# Altium schematic sheet 13-M2_OCP_GNSS.SchDoc(13-M2_OCP_GNSS)
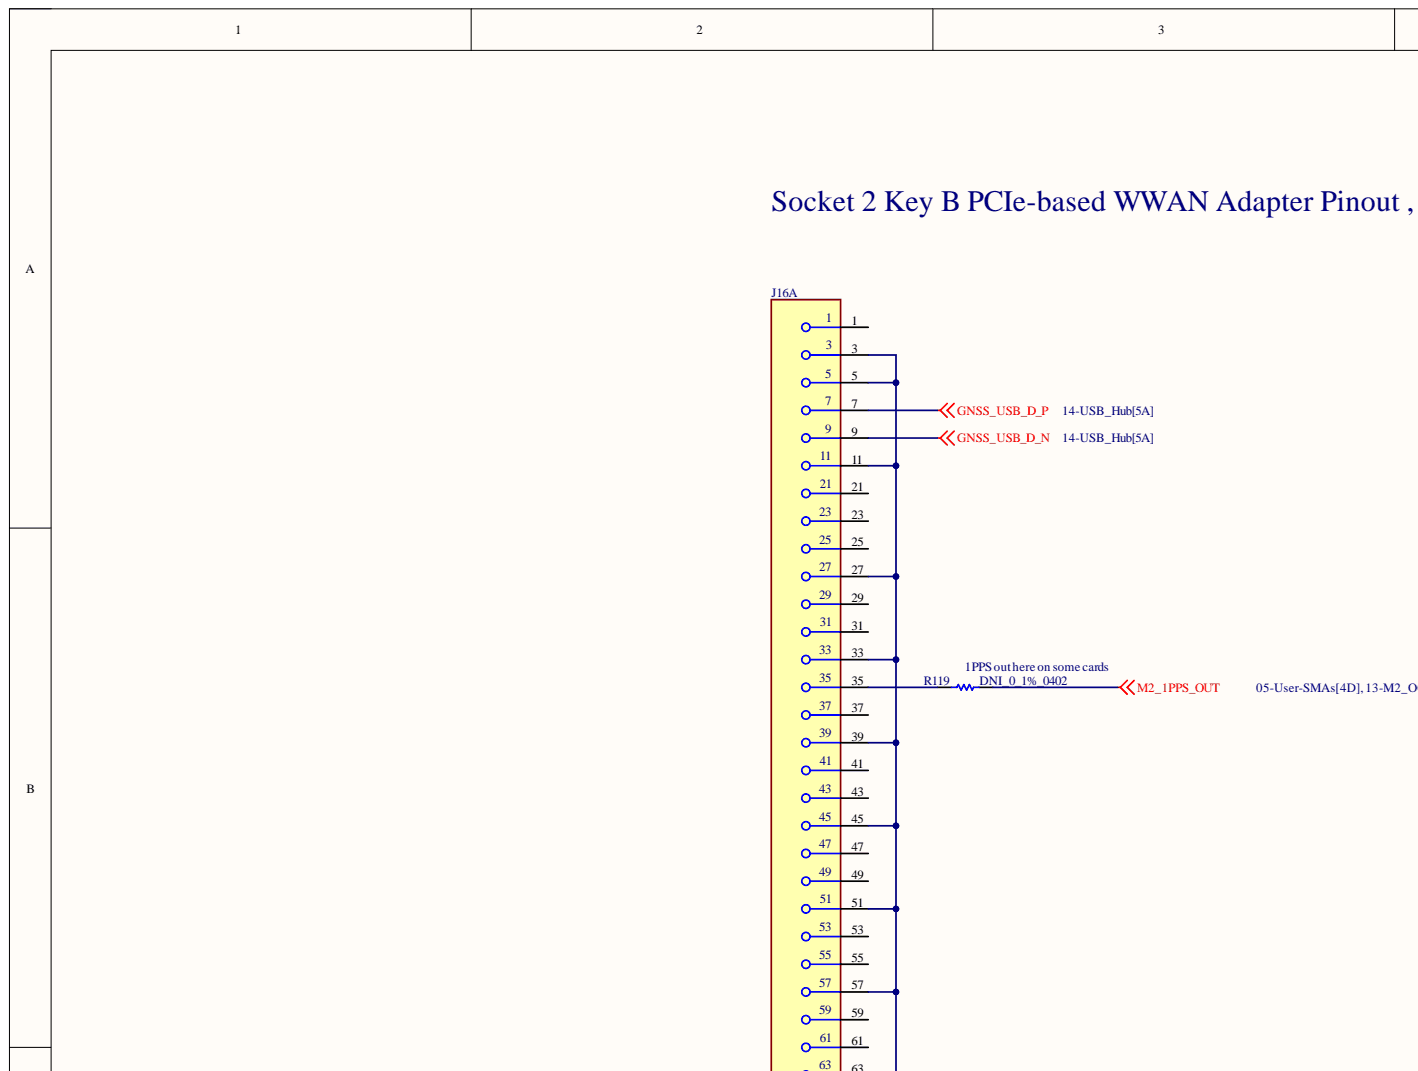
[diagram p0: 13-M2_OCP_GNSS.SchDoc, top left]
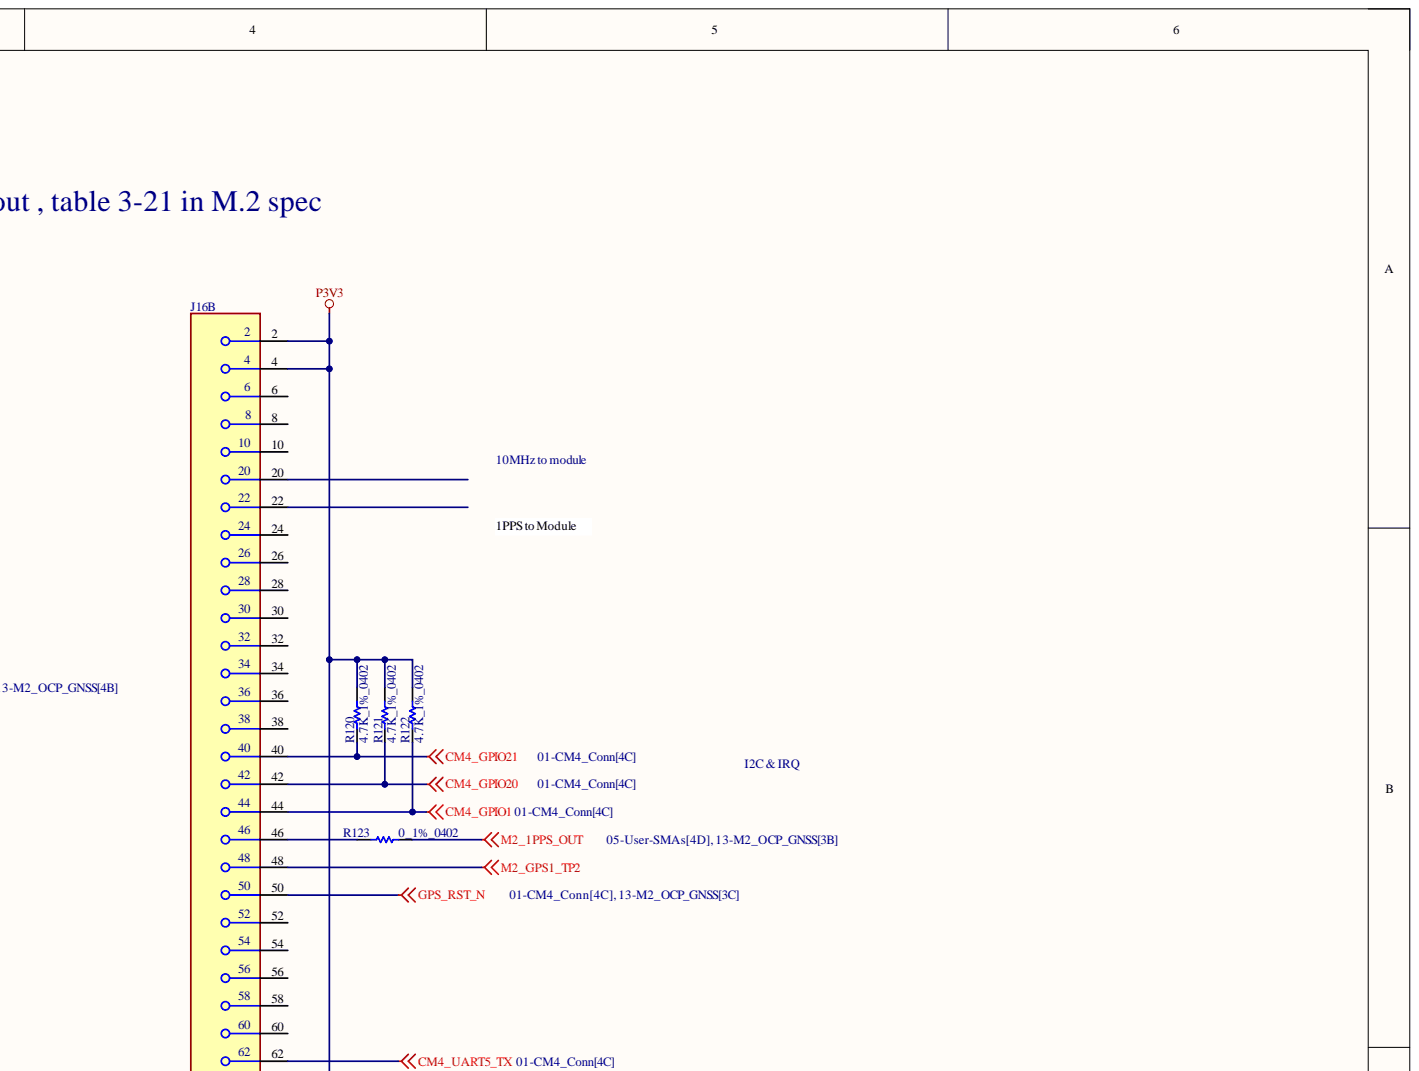
[diagram p1: 13-M2_OCP_GNSS.SchDoc, top right]
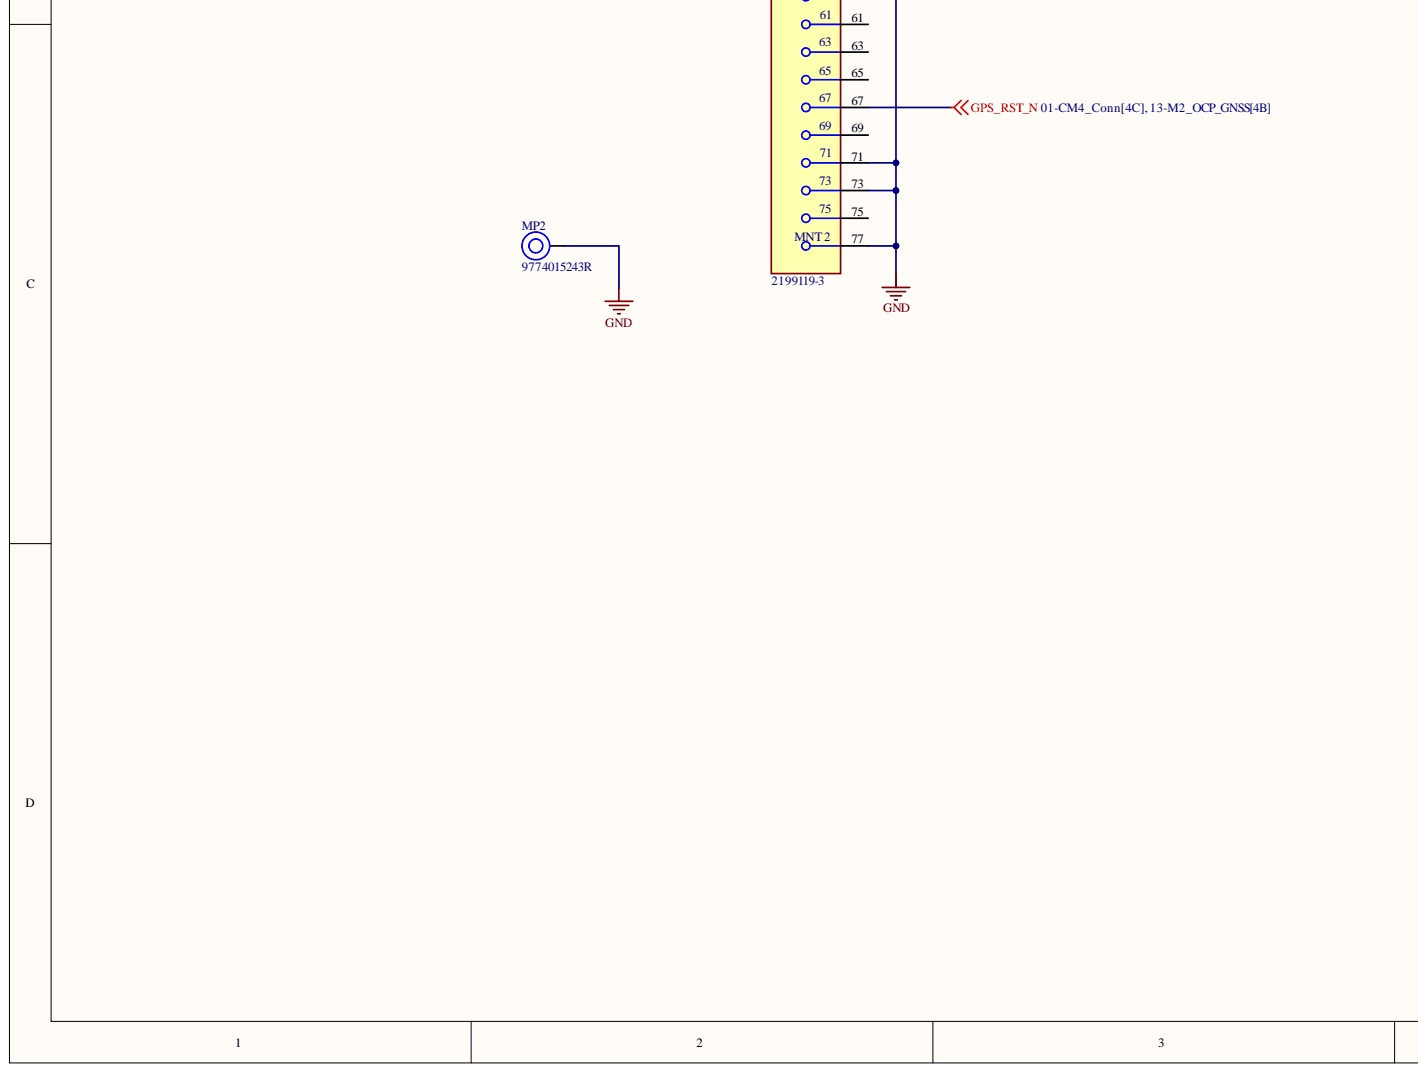
[diagram p2: 13-M2_OCP_GNSS.SchDoc, bottom left]
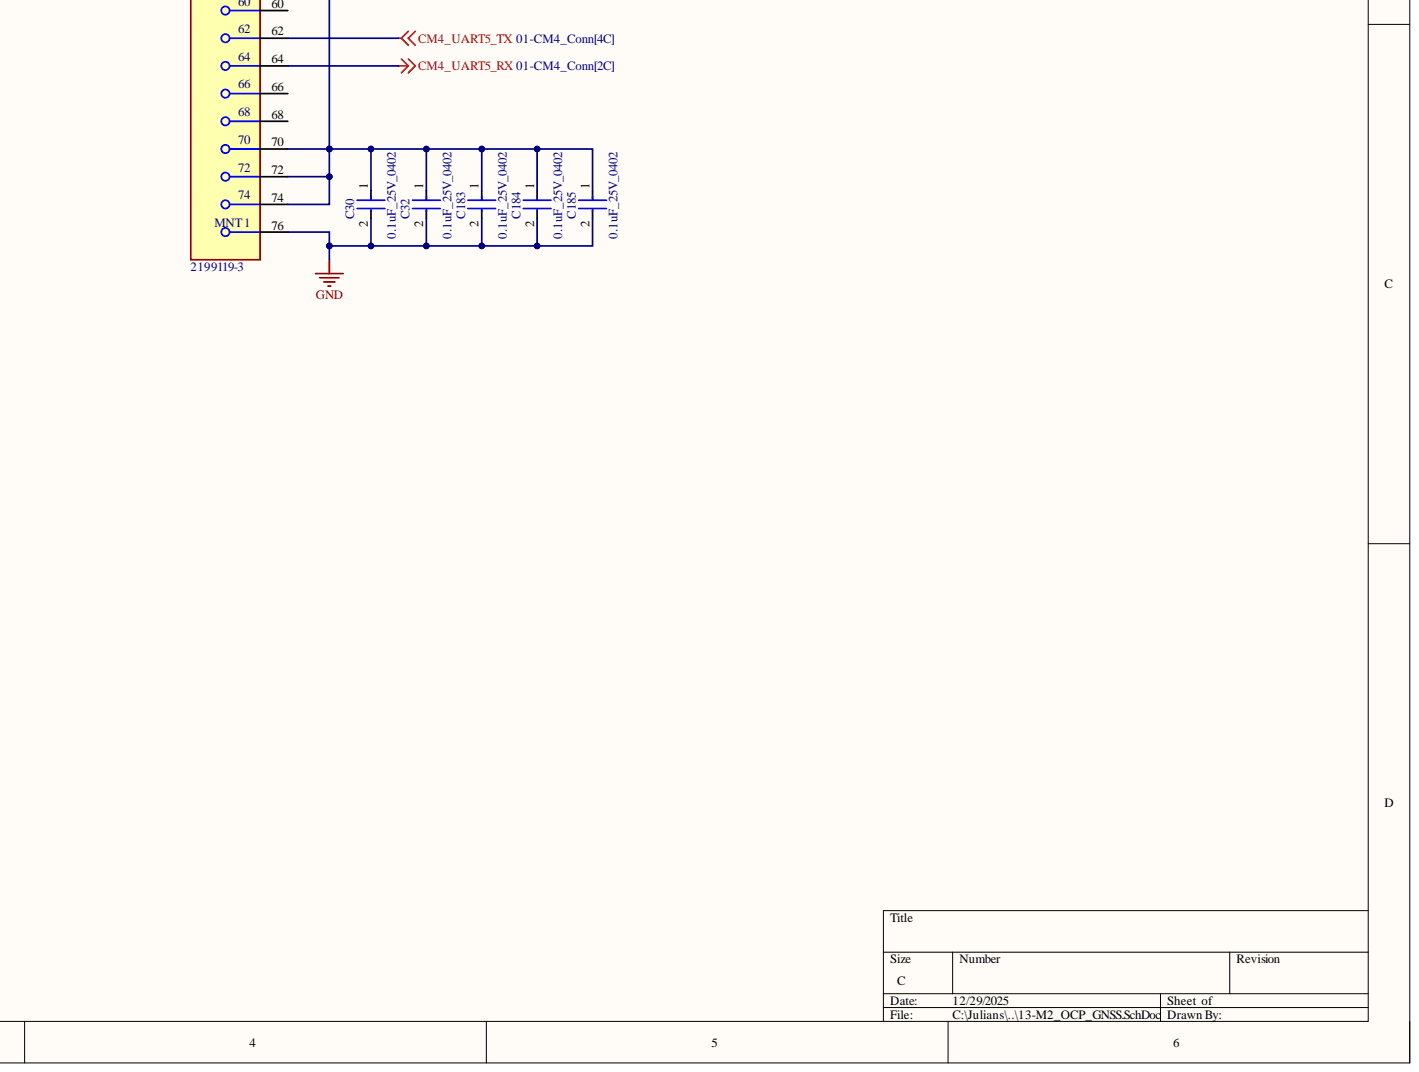
[diagram p3: 13-M2_OCP_GNSS.SchDoc, bottom right]

## Parts
C30  0.1uF_25V_0402  [FP-0402-L_1_0_1-W_0_5_0_1-IPC_C]
C32  0.1uF_25V_0402  [FP-0402-L_1_0_1-W_0_5_0_1-IPC_C]
C183  0.1uF_25V_0402  [FP-0402-L_1_0_1-W_0_5_0_1-IPC_C]
C184  0.1uF_25V_0402  [FP-0402-L_1_0_1-W_0_5_0_1-IPC_C]
C185  0.1uF_25V_0402  [FP-0402-L_1_0_1-W_0_5_0_1-IPC_C]
J16  2199119-3  [FP-2199119-3-MFG]  (parts A,B)
MP2  9774015243R  [9774015243]
R119  DNI_0_1%_0402  [RESC1005X40X25LL05T05]  (no BOM)
R120  4.7K_1%_0402  [RESC1005X40X25NL10T10]
R121  4.7K_1%_0402  [RESC1005X40X25NL10T10]
R122  4.7K_1%_0402  [RESC1005X40X25NL10T10]
R123  0_1%_0402  [RESC1005X40X25LL05T05]

## Nets
CM4_GPIO1: J16.44 R122.1
CM4_GPIO20: J16.42 R121.1
CM4_GPIO21: J16.40 R120.1
CM4_UART5_RX: J16.64
CM4_UART5_TX: J16.62
GND: C30.2 C32.2 C183.2 C184.2 C185.2 J16.3 J16.5 J16.11 J16.27 J16.33 J16.39 J16.45 J16.51 J16.57 J16.71 J16.73 J16.76 J16.77 MP2.1
GNSS_USB_D_N: J16.9
GNSS_USB_D_P: J16.7
GPS_RST_N: J16.50 J16.67
M2_1PPS_OUT: R119.2 R123.2
M2_GPS1_TP2: J16.48
P3V3: C30.1 C32.1 C183.1 C184.1 C185.1 J16.2 J16.4 J16.70 J16.72 J16.74 R120.2 R121.2 R122.2
NetJ16_35: J16.35 R119.1
NetJ16_46: J16.46 R123.1

Not connected: J16 1 6 8 10 20 21 22 23 24 25 26 28 29 30 31 32 34 36 37 38 41 43 47 49 52 53 54 55 56 58 59 60 61 63 65 66 68 69 75

## Library data of the parts
C30 C32 C183-C185 = CMP-2008-02519-2: General Purpose Ceramic Capacitor, 0402, 100nF, 10%, X7R, 15%, 25V; Capacitance: 100nF; Case Code (Imperial): 0402; Case Code (Metric): 1005; Case/Package: 0402; Component Kind: Standard; Construction: Flat; Depth: 0.5mm; Dielectric: X7R; Lead Free: Lead Free; Length: 1mm; Library Name: Altium Content Vault; Max Operating Temperature: 125°C; Min Operating Temperature: -55°C; Mount: Surface Mount; Number of Pins: 2; Package Quantity: 1; Packaging: Tape and Reel; Radiation Hardening: No; REACH SVHC: No SVHC; RoHS Compliant: Yes; Series: -; Termination: SMD/SMT; Thickness: 0.022inch; Tolerance: 10%; Voltage: 25V; Voltage Rating: 25V; Voltage Rating (DC): 25V; Width: 0.02inch
J16 = CMP-03365-000004-1: CONN M.2 FEMALE 67POS 0.020 GOLD; Contact Current Rating: 500mA; Insulation Resistance: 500MR; Max Voltage Rating (AC): 50V; Number of Contacts: 67; PCB Mounting Orientation: Right Angle; Pitch: 0.5mm; Row Spacing: 7.55mm; Color: Black; Component Kind: Standard; Contact Material: Copper Alloy; Contact Plating: Gold; Current Rating: 500mA; ELV: Compliant; Gender: Female; Height: 3.2mm; Housing Color: Black; Housing Material: Thermoplastic; Insulation Material: Thermoplastic; Length: 0.862inch; Library Name: Altium Content Vault; Max Operating Temperature: 80°C; Min Operating Temperature: -40°C; Mount: Surface Mount; Number of Positions: 67; Number of Rows: 2; Orientation: Right Angle; Package Quantity: 1200; Packaging: Tape and Reel; Radiation Hardening: No; RoHS Compliant: Yes; Termination: Solder; Voltage Rating: 50V; Width: 8.7mm
MP2 = CMP-03913-59774847-1: 9774015243R Spacer Material: Steel, Tin-Plated Mounting: SMT Series: WA-SMST Thread D: M2; Outside Diameter: 4.3434mm; Plating: Tin; Threaded/Unthreaded: Threaded; Component Kind: Standard; Height: 1.6mm; Length: 1.5mm; Library Name: Altium Content Vault; Material: Steel; Max Operating Temperature: 150°C; Min Operating Temperature: -55°C; NexarPartId: 59774847; Packaging: Tape and Reel; REACH SVHC: Unknown; RoHS: Compliant
R119 R123 = CMP-2000-07451-1: Chip Resistor, 0 Ohm, 0.1 W, -55 to 155 degC, 0402 (1005 Metric), RoHS, Tape and Reel; Manufacturer: Panasonic; Max Power Dissipation: 100mW; Resistance: 0mOhm; Temperature Coefficient: 600ppm/��C; Tolerance: 5%; Voltage Rating: 50V; Case Code (Imperial): 0402; Case Code (Metric): 1005; Case/Package: 0402; Composition: ThickFilm; Contact Plating: Tin; Datasheet URL: https://industrial.panasonic.com/cdbs/www-data/pdf/RDA0000/AOA0000C301.pdf; Datasheet Version: 03/2015; Depth: 0.5mm; Height: 0.35mm; Lead Free: LeadFree; Length: 1mm; Library Name: Altium Content Vault; Manufacturer URL: http://www.panasonic.com/; Max Operating Temperature: 155degC; Military Standard: Not; Min Operating Temperature: -55degC; Mount: SurfaceMount; Mounting Technology: SurfaceMount; Number of Terminations: 2; Package Description: 2-Pin Surface Mount Device, Body 1 x 0.5 mm; Package Quantity: 1; Package Reference: 402; Packaging: TapeandReel; Power Rating: 100mW; Radiation Hardening: No; REACH SVHC: NoSVHC; RoHSCompliant: True; Termination: SMD/SMT; Voltage Rating (DC): 50V; Width: 0.02inch
  R123: Component Kind: Standard
R120-R122 = CMP-2002-05217-1: Manufacturer: Bourns; Part Number: CR0402-FX-4701GLF; Component Kind: Standard; Library Name: Altium Content Vault
